# Altium schematic sheet MCU.SchDoc(MCU)
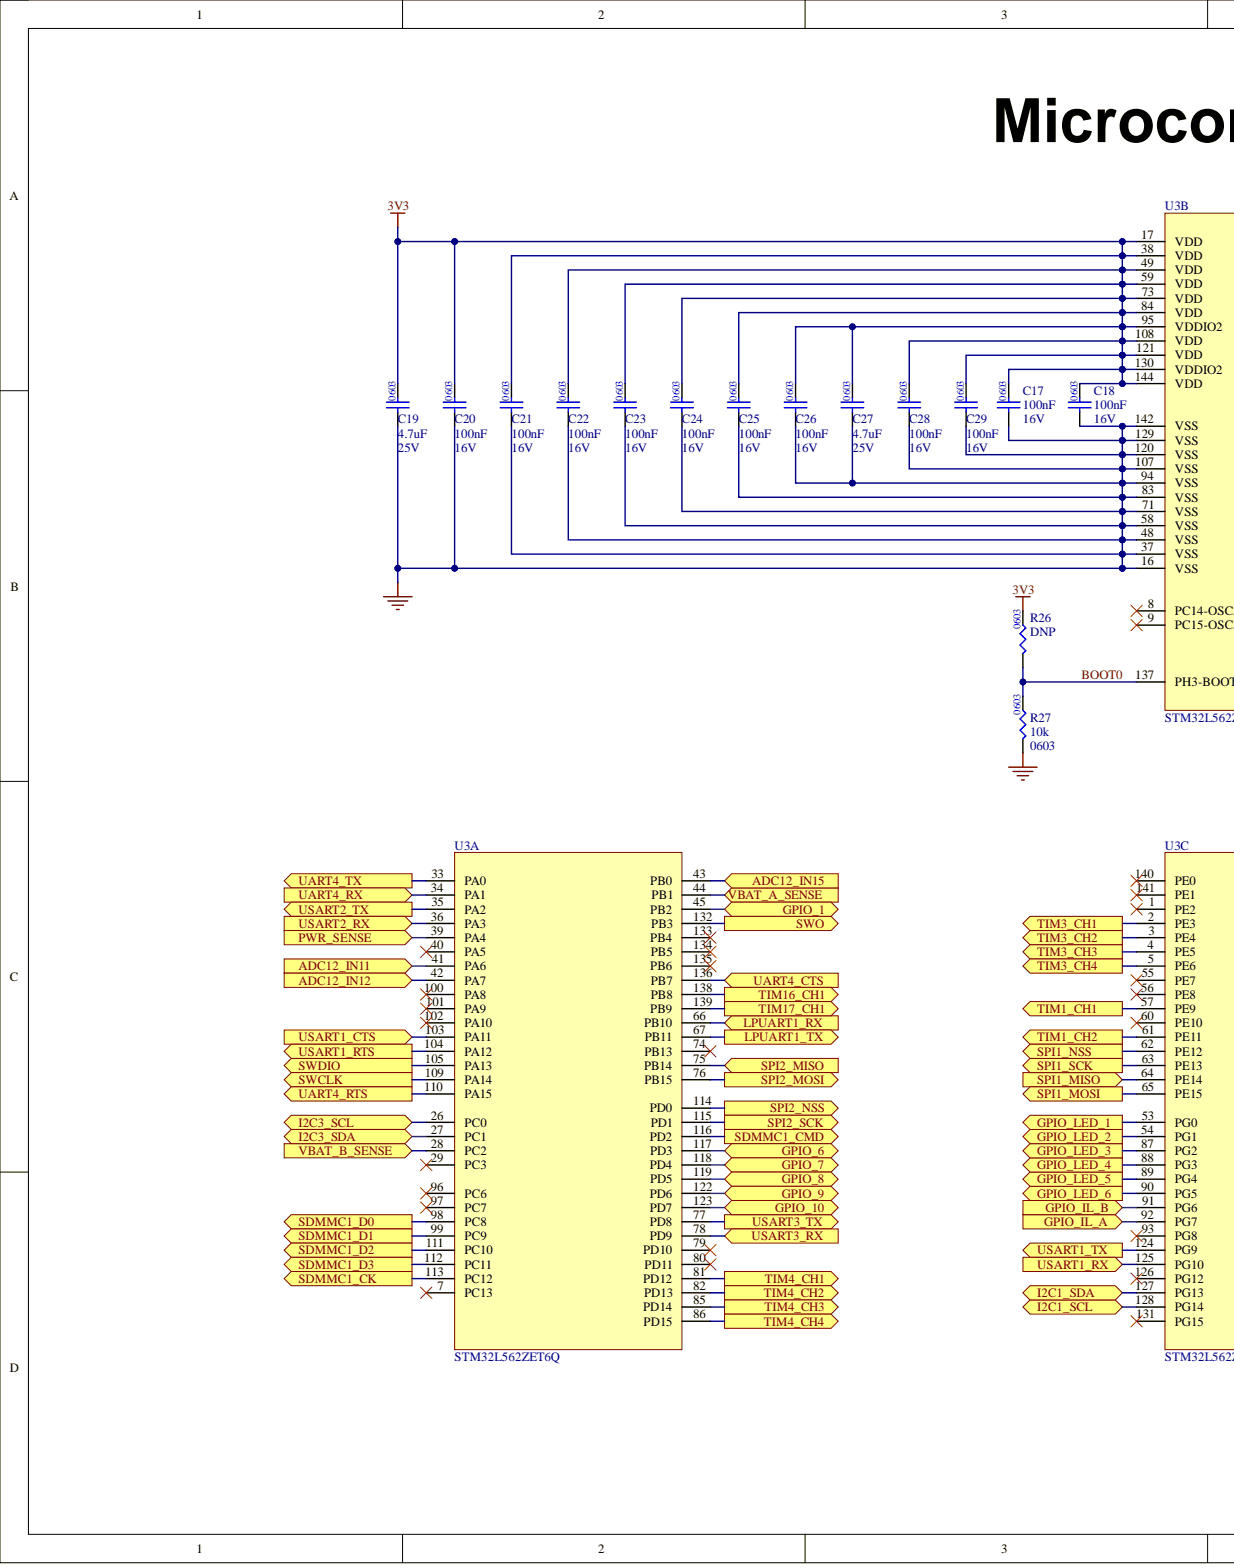
[diagram p0: MCU.SchDoc, left]
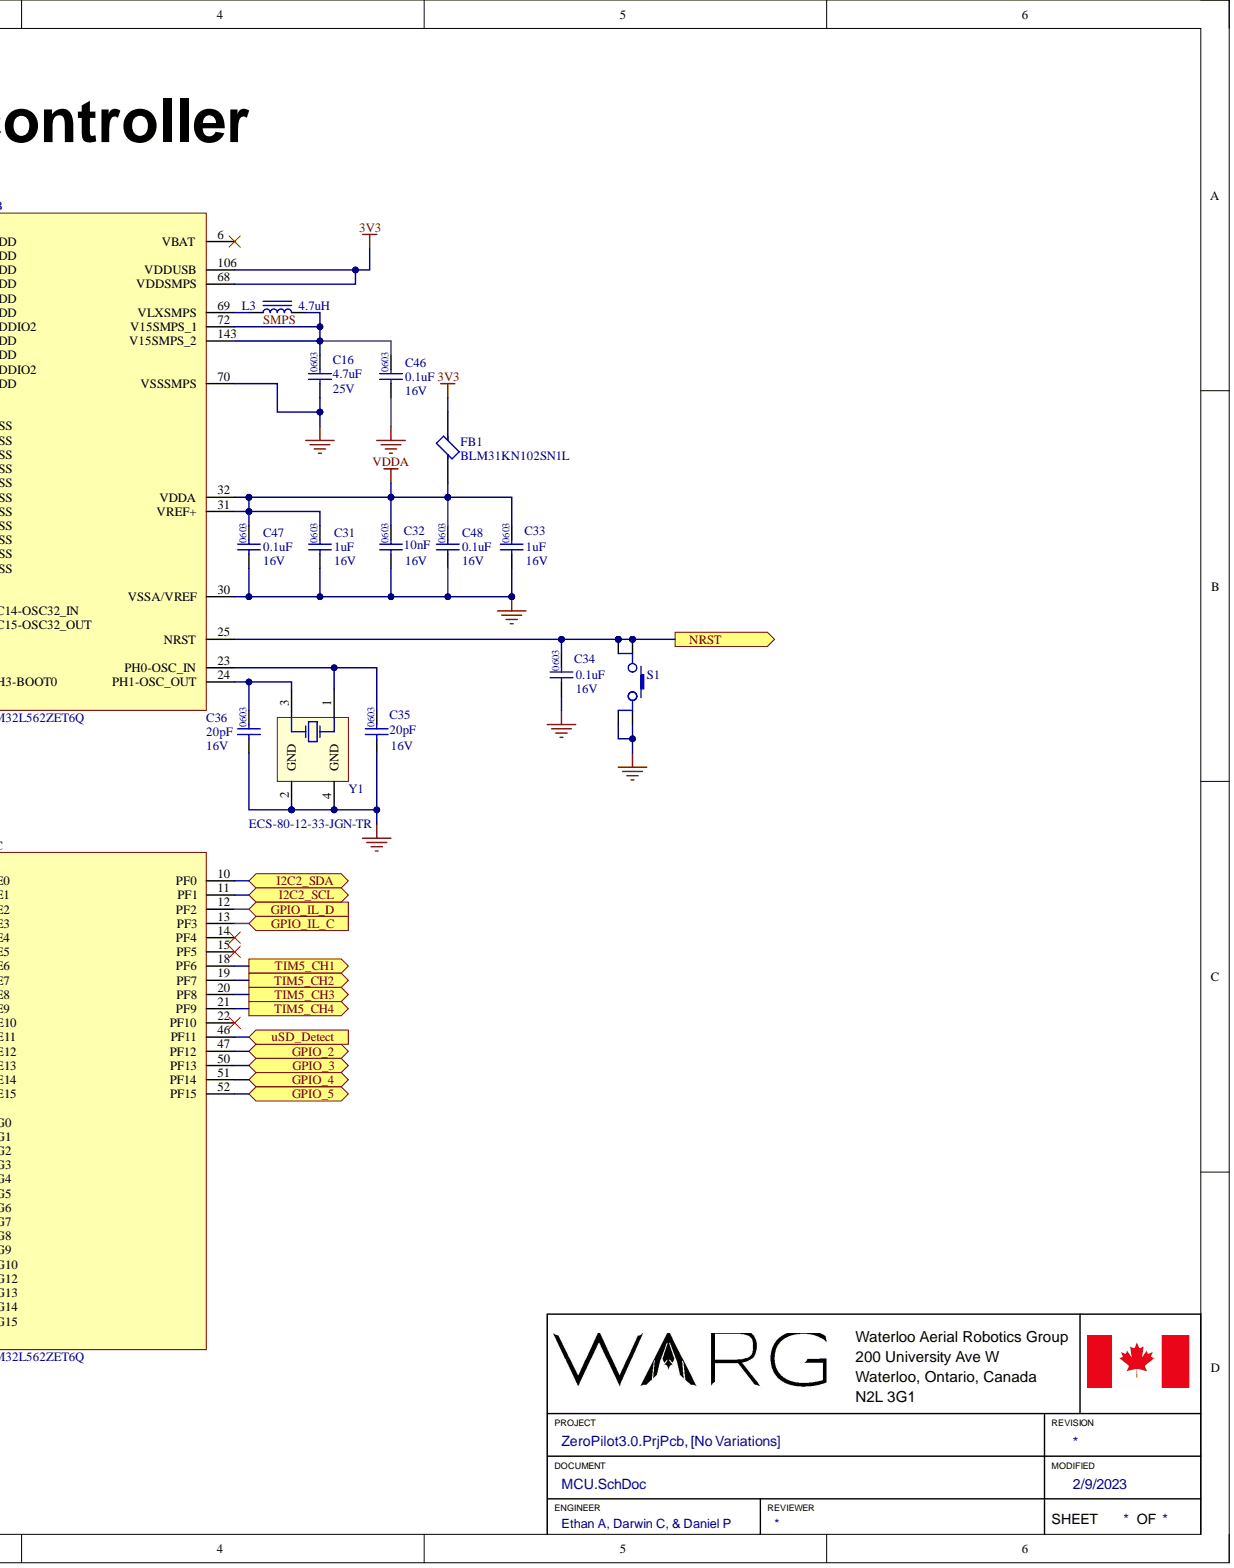
[diagram p1: MCU.SchDoc, right]

## Parts
C16  value 4.7uF  Capacitance: 4.7uF  Package: 0603  Voltage: 25V  (hidden comment: GRM188C81E475KE11D)
C17  value 100nF  Capacitance: 100nF  Package: 0603  Voltage: 16V  (hidden comment: GCM188R71C104KA37D)
C18  value 100nF  Capacitance: 100nF  Package: 0603  Voltage: 16V  (hidden comment: GCM188R71C104KA37D)
C19  value 4.7uF  Capacitance: 4.7uF  Package: 0603  Voltage: 25V  (hidden comment: GRM188C81E475KE11D)
C20  value 100nF  Capacitance: 100nF  Package: 0603  Voltage: 16V  (hidden comment: GCM188R71C104KA37D)
C21  value 100nF  Capacitance: 100nF  Package: 0603  Voltage: 16V  (hidden comment: GCM188R71C104KA37D)
C22  value 100nF  Capacitance: 100nF  Package: 0603  Voltage: 16V  (hidden comment: GCM188R71C104KA37D)
C23  value 100nF  Capacitance: 100nF  Package: 0603  Voltage: 16V  (hidden comment: GCM188R71C104KA37D)
C24  value 100nF  Capacitance: 100nF  Package: 0603  Voltage: 16V  (hidden comment: GCM188R71C104KA37D)
C25  value 100nF  Capacitance: 100nF  Package: 0603  Voltage: 16V  (hidden comment: GCM188R71C104KA37D)
C26  value 100nF  Capacitance: 100nF  Package: 0603  Voltage: 16V  (hidden comment: GCM188R71C104KA37D)
C27  value 4.7uF  Capacitance: 4.7uF  Package: 0603  Voltage: 25V  (hidden comment: GRM188C81E475KE11D)
C28  value 100nF  Capacitance: 100nF  Package: 0603  Voltage: 16V  (hidden comment: GCM188R71C104KA37D)
C29  value 100nF  Capacitance: 100nF  Package: 0603  Voltage: 16V  (hidden comment: GCM188R71C104KA37D)
C31  value 1uF  Capacitance: 1uF  Package: 0603  Voltage: 16V  (hidden comment: GCM188R71C105KA64J)
C32  value 10nF  Capacitance: 10nF  Package: 0603  Voltage: 16V  (hidden comment: CC0603KRX7R7BB103)
C33  value 1uF  Capacitance: 1uF  Package: 0603  Voltage: 16V  (hidden comment: GCM188R71C105KA64J)
C34  value 0.1uF  Capacitance: 0.1uF  Package: 0603  Voltage: 16V  (hidden comment: GCM188R71C104KA37D)
C35  value 20pF  Package: 0603  Voltage: 16V  (hidden comment: C0603C200K4HACAUTO)
C36  value 20pF  Package: 0603  Voltage: 16V  (hidden comment: C0603C200K4HACAUTO)
C46  value 0.1uF  Capactitance: 0.1uF  Package: 0603  Voltage: 16V  (hidden comment: GCM188R71C104KA37D)
C47  value 0.1uF  Capactitance: 0.1uF  Package: 0603  Voltage: 16V  (hidden comment: GCM188R71C104KA37D)
C48  value 0.1uF  Capactitance: 0.1uF  Package: 0603  Voltage: 16V  (hidden comment: GCM188R71C104KA37D)
FB1  BLM31KN102SN1L
L3  value 4.7uH  Inductance: 4.7uH  (hidden comment: MLP2016H4R7MT0S1)
R26  value DNP  (hidden comment: .RES_0603_DNP)
R27  value 10k  Package: 0603  Resistance: 10k  (hidden comment: RC0603FR-0710KL)
S1  SWITCH_SPST_NO  (value not drawn)
U3  STM32L562ZET6Q  (parts A,B,C)
Y1  ECS-80-12-33-JGN-TR

## Ports
ADC12_IN11, ADC12_IN12, ADC12_IN15, GPIO_1, GPIO_2, GPIO_3, GPIO_4, GPIO_5, GPIO_6, GPIO_7, GPIO_8, GPIO_9, GPIO_10, GPIO_IL_A, GPIO_IL_B, GPIO_IL_C, GPIO_IL_D, GPIO_LED_1, GPIO_LED_2, GPIO_LED_3, GPIO_LED_4, GPIO_LED_5, GPIO_LED_6, I2C1_SCL, I2C1_SDA, I2C2_SCL, I2C2_SDA, I2C3_SCL, I2C3_SDA, LPUART1_RX, LPUART1_TX, NRST, PWR_SENSE, SDMMC1_CK, SDMMC1_CMD, SDMMC1_D0, SDMMC1_D1, SDMMC1_D2, SDMMC1_D3, SPI1_MISO, SPI1_MOSI, SPI1_NSS, SPI1_SCK, SPI2_MISO, SPI2_MOSI, SPI2_NSS, SPI2_SCK, SWCLK, SWDIO, SWO, TIM1_CH1, TIM1_CH2, TIM3_CH1, TIM3_CH2, TIM3_CH3, TIM3_CH4, TIM4_CH1, TIM4_CH2, TIM4_CH3, TIM4_CH4, TIM5_CH1, TIM5_CH2, TIM5_CH3, TIM5_CH4, TIM16_CH1, TIM17_CH1, UART4_CTS, UART4_RTS, UART4_RX, UART4_TX, USART1_CTS, USART1_RTS, USART1_RX, USART1_TX, USART2_RX, USART2_TX, USART3_RX, USART3_TX, uSD_Detect, VBAT_A_SENSE, VBAT_B_SENSE

## Nets
3V3: C17.2 C18.2 C19.2 C20.2 C21.2 C22.2 C23.2 C24.2 C25.2 C26.2 C27.2 C28.2 C29.2 FB1.2 R26.2 U3.17(VDD) U3.38(VDD) U3.49(VDD) U3.59(VDD) U3.68(VDDSMPS) U3.73(VDD) U3.84(VDD) U3.95(VDDIO2) U3.106(VDDUSB) U3.108(VDD) U3.121(VDD) U3.130(VDDIO2) U3.144(VDD)
GND: C16.1 C17.1 C18.1 C19.1 C20.1 C21.1 C22.1 C23.1 C24.1 C25.1 C26.1 C27.1 C28.1 C29.1 C31.1 C32.1 C33.1 C34.1 C35.1 C36.1 C46.1 C47.1 C48.1 R27.1 S1.3 S1.4 U3.16(VSS) U3.30(VSSA/VREF) U3.37(VSS) U3.48(VSS) U3.58(VSS) U3.70(VSSSMPS) U3.71(VSS) U3.83(VSS) U3.94(VSS) U3.107(VSS) U3.120(VSS) U3.129(VSS) U3.142(VSS) Y1.2(GND) Y1.4(GND)
VDDA: C31.2 C32.2 C33.2 C47.2 C48.2 FB1.1 U3.31(VREF+) U3.32(VDDA)
ADC12_IN11: U3.41(PA6) ; port ADC12_IN11
ADC12_IN12: U3.42(PA7) ; port ADC12_IN12
ADC12_IN15: U3.43(PB0) ; port ADC12_IN15
BOOT0: R26.1 R27.2 U3.137(PH3-BOOT0)
GPIO_1: U3.45(PB2) ; port GPIO_1
GPIO_2: U3.47(PF12) ; port GPIO_2
GPIO_3: U3.50(PF13) ; port GPIO_3
GPIO_4: U3.51(PF14) ; port GPIO_4
GPIO_5: U3.52(PF15) ; port GPIO_5
GPIO_6: U3.117(PD3) ; port GPIO_6
GPIO_7: U3.118(PD4) ; port GPIO_7
GPIO_8: U3.119(PD5) ; port GPIO_8
GPIO_9: U3.122(PD6) ; port GPIO_9
GPIO_10: U3.123(PD7) ; port GPIO_10
GPIO_IL_A: U3.92(PG7) ; port GPIO_IL_A
GPIO_IL_B: U3.91(PG6) ; port GPIO_IL_B
GPIO_IL_C: U3.13(PF3) ; port GPIO_IL_C
GPIO_IL_D: U3.12(PF2) ; port GPIO_IL_D
GPIO_LED_1: U3.53(PG0) ; port GPIO_LED_1
GPIO_LED_2: U3.54(PG1) ; port GPIO_LED_2
GPIO_LED_3: U3.87(PG2) ; port GPIO_LED_3
GPIO_LED_4: U3.88(PG3) ; port GPIO_LED_4
GPIO_LED_5: U3.89(PG4) ; port GPIO_LED_5
GPIO_LED_6: U3.90(PG5) ; port GPIO_LED_6
I2C1_SCL: U3.128(PG14) ; port I2C1_SCL
I2C1_SDA: U3.127(PG13) ; port I2C1_SDA
I2C2_SCL: U3.11(PF1) ; port I2C2_SCL
I2C2_SDA: U3.10(PF0) ; port I2C2_SDA
I2C3_SCL: U3.26(PC0) ; port I2C3_SCL
I2C3_SDA: U3.27(PC1) ; port I2C3_SDA
LPUART1_RX: U3.66(PB10) ; port LPUART1_RX
LPUART1_TX: U3.67(PB11) ; port LPUART1_TX
NRST: C34.2 S1.1 S1.2 U3.25(NRST) ; port NRST
PWR_SENSE: U3.39(PA4) ; port PWR_SENSE
SDMMC1_CK: U3.113(PC12) ; port SDMMC1_CK
SDMMC1_CMD: U3.116(PD2) ; port SDMMC1_CMD
SDMMC1_D0: U3.98(PC8) ; port SDMMC1_D0
SDMMC1_D1: U3.99(PC9) ; port SDMMC1_D1
SDMMC1_D2: U3.111(PC10) ; port SDMMC1_D2
SDMMC1_D3: U3.112(PC11) ; port SDMMC1_D3
SMPS: C16.2 C46.2 L3.2 U3.72(V15SMPS_1) U3.143(V15SMPS_2)
SPI1_MISO: U3.64(PE14) ; port SPI1_MISO
SPI1_MOSI: U3.65(PE15) ; port SPI1_MOSI
SPI1_NSS: U3.62(PE12) ; port SPI1_NSS
SPI1_SCK: U3.63(PE13) ; port SPI1_SCK
SPI2_MISO: U3.75(PB14) ; port SPI2_MISO
SPI2_MOSI: U3.76(PB15) ; port SPI2_MOSI
SPI2_NSS: U3.114(PD0) ; port SPI2_NSS
SPI2_SCK: U3.115(PD1) ; port SPI2_SCK
SWCLK: U3.109(PA14) ; port SWCLK
SWDIO: U3.105(PA13) ; port SWDIO
SWO: U3.132(PB3) ; port SWO
TIM1_CH1: U3.57(PE9) ; port TIM1_CH1
TIM1_CH2: U3.61(PE11) ; port TIM1_CH2
TIM3_CH1: U3.2(PE3) ; port TIM3_CH1
TIM3_CH2: U3.3(PE4) ; port TIM3_CH2
TIM3_CH3: U3.4(PE5) ; port TIM3_CH3
TIM3_CH4: U3.5(PE6) ; port TIM3_CH4
TIM4_CH1: U3.81(PD12) ; port TIM4_CH1
TIM4_CH2: U3.82(PD13) ; port TIM4_CH2
TIM4_CH3: U3.85(PD14) ; port TIM4_CH3
TIM4_CH4: U3.86(PD15) ; port TIM4_CH4
TIM5_CH1: U3.18(PF6) ; port TIM5_CH1
TIM5_CH2: U3.19(PF7) ; port TIM5_CH2
TIM5_CH3: U3.20(PF8) ; port TIM5_CH3
TIM5_CH4: U3.21(PF9) ; port TIM5_CH4
TIM16_CH1: U3.138(PB8) ; port TIM16_CH1
TIM17_CH1: U3.139(PB9) ; port TIM17_CH1
UART4_CTS: U3.136(PB7) ; port UART4_CTS
UART4_RTS: U3.110(PA15) ; port UART4_RTS
UART4_RX: U3.34(PA1) ; port UART4_RX
UART4_TX: U3.33(PA0) ; port UART4_TX
USART1_CTS: U3.103(PA11) ; port USART1_CTS
USART1_RTS: U3.104(PA12) ; port USART1_RTS
USART1_RX: U3.125(PG10) ; port USART1_RX
USART1_TX: U3.124(PG9) ; port USART1_TX
USART2_RX: U3.36(PA3) ; port USART2_RX
USART2_TX: U3.35(PA2) ; port USART2_TX
USART3_RX: U3.78(PD9) ; port USART3_RX
USART3_TX: U3.77(PD8) ; port USART3_TX
uSD_Detect: U3.46(PF11) ; port uSD_Detect
VBAT_A_SENSE: U3.44(PB1) ; port VBAT_A_SENSE
VBAT_B_SENSE: U3.28(PC2) ; port VBAT_B_SENSE
NetC35_2: C35.2 U3.23(PH0-OSC_IN) Y1.1
NetC36_2: C36.2 U3.24(PH1-OSC_OUT) Y1.3
NetL3_1: L3.1 U3.69(VLXSMPS)

Not connected: U3 1(PE2) 6(VBAT) 7(PC13) 8(PC14-OSC32_IN) 9(PC15-OSC32_OUT) 14(PF4) 15(PF5) 22(PF10) 29(PC3) 40(PA5) 55(PE7) 56(PE8) 60(PE10) 74(PB13) 79(PD10) 80(PD11) 93(PG8) 96(PC6) 97(PC7) 100(PA8) 101(PA9) 102(PA10) 126(PG12) 131(PG15) 133(PB4) 134(PB5) 135(PB6) 140(PE0) 141(PE1)

## Library data of the parts
C16 C19 C27 = CMP-001-00002-8: CAP CER 4.7uF 25V X6S 0603; Component Kind: Standard; Library Name: WARG
C17 C18 C20-C26 C28 C29 = CMP-001-00003-8: CAP CER 100nF 16V X7R 0603; Component Kind: Standard; Library Name: WARG
C31 C33 = CMP-001-00007-6: CAP CER 1uF 16V X7R 0603; Component Kind: Standard; Library Name: WARG
C32 = CMP-001-00004-10: CAP CER 10nF 16V X7R 0603; Component Kind: Standard; Library Name: WARG
C34 = CMP-001-00017-6: CAP CER 0.1UF 16V X7R 0603; Component Kind: Standard; Temperature Coefficient: X7R; Library Name: WARG
C35 C36 = CMP-001-00021-6: CAP CER 20pF 16V 0603 ULTRA STAB; Temperature Coefficient: X8R; Component Kind: Standard; Library Name: WARG
C46-C48 = CMP-001-00020-5: CAP CER 0.1uF 16V X7R 0603; Component Kind: Standard; Temperature Coefficient: X7R; Library Name: WARG
FB1 = CMP-00006-2: FERRITE BEAD 1 KOHM 1206 1LN; DC Resistance (DCR): 75mR; Component Kind: Standard; Package: 1206; Library Name: WARG
L3 = CMP-006-00001-4: FIXED IND 4.7uH 1.1A 200MOHM SMD; Resistance: 200M; Component Kind: Standard; Current: 1.1A; Library Name: WARG
R26 = CMP-009-00018-3: DNP 0603 Resistor; Component Kind: Standard; Library Name: WARG
R27 = CMP-009-00006-4: RES 10K OHM 1% 1/10W 0603; Component Kind: Standard; Power: 1/10W; Library Name: WARG
S1 = CMP-011-00000-4: SWITCH TACTILE SPST-NO 0.05A 12V; Manufacturer Part Number: TL3301NF160QG/TR; Component Kind: Standard; Mounting Type: Surface Mount; Supplier: Digi-Key; Supplier Part Number: EG1867CT-ND; Library Name: WARG
U3 = CMP-00000-18: MCU 32-Bit STM32 ARM Cortex-M33F RISC 512KB Flash 1.71V to 3.6V 144-Pin LQFP Tray; Component Kind: Standard; Datasheet: https://www.st.com/resource/en/datasheet/stm32l562ve.pdf; Library Name: WARG
Y1 = CMP-004-000000-2: 8 MHz ±20ppm Crystal 12pF 400 Ohms 4-SMD; Manufacturer 1: ECS Inc.; Equivalent Series Resistance: 50Ω; Frequency: 8MHz; Frequency Tolerance: ±10ppm; Load Capacitance: 10pF; Component Kind: Standard; Design Item ID: ECS-80-12-33-JGN-TR; Frequency Stability: ±20ppm; Manufacturer Part Number 1: ECS-80-12-33-JGN-TR; Library Name: WARG; Source: Bootcamp SchLib.SchLib
